# Altium schematic sheet 3phasedriver.SchDoc(3phasedriver)
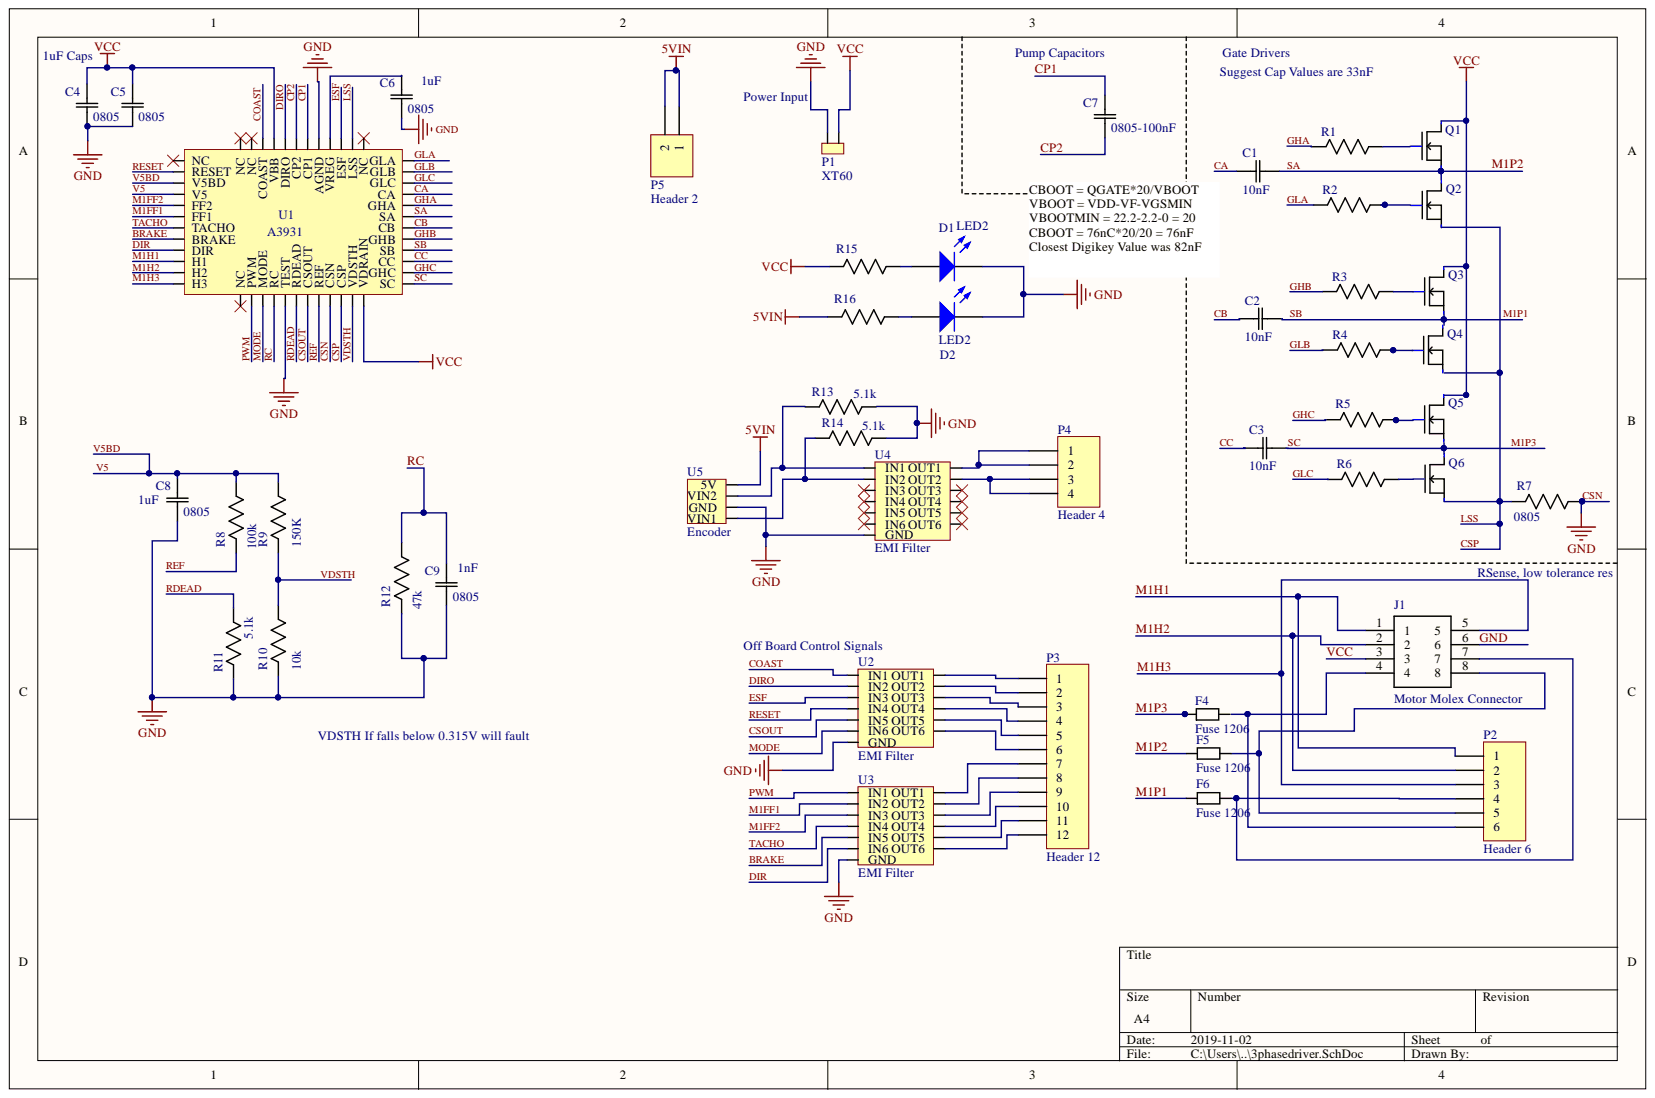
[diagram p0: 3phasedriver.SchDoc]

## Parts
C1  10nF  [CAPC2013X110N]
C2  10nF  [CAPC2013X110N]
C3  10nF  [CAPC2013X110N]
C4  0805  [CAPC2013X110N]
C5  0805  [CAPC2013X110N]
C6  0805  [CAPC2013X110N]
C7  0805-100nF  [CAPC2013X110N]
C8  0805  [CAPC2013X110N]
C9  0805  [CAPC2013X110N]
D1  LED2  [3.2X1.6X1.1]
D2  LED2  [3.2X1.6X1.1]
F4  Fuse 1206  [PCBComponent_1]
F5  Fuse 1206  [PCBComponent_1]
F6  Fuse 1206  [PCBComponent_1]
J1  Motor Molex Connector  MF: Molex  [*MOLEX_0039281083]
P1  XT60  [PCBComponent_1]
P2  Header 6  [HDR1X6]
P3  Header 12  [HDR1X12]
P4  Header 4  [HDR1X4]
P5  Header 2  [HDR1X2]
Q1  N-Channel  [SOIC127P600X170-8N]  (value not drawn)
Q2  N-Channel  [SOIC127P600X170-8N]  (value not drawn)
Q3  N-Channel  [SOIC127P600X170-8N]  (value not drawn)
Q4  N-Channel  [SOIC127P600X170-8N]  (value not drawn)
Q5  N-Channel  [SOIC127P600X170-8N]  (value not drawn)
Q6  N-Channel  [SOIC127P600X170-8N]  (value not drawn)
R1  RES SMD 0 OHM JUMPER 1/8W 0805  [RESC2013X65N]  (value not drawn)
R2  RES SMD 0 OHM JUMPER 1/8W 0805  [RESC2013X65N]  (value not drawn)
R3  RES SMD 0 OHM JUMPER 1/8W 0805  [RESC2013X65N]  (value not drawn)
R4  RES SMD 0 OHM JUMPER 1/8W 0805  [RESC2013X65N]  (value not drawn)
R5  RES SMD 0 OHM JUMPER 1/8W 0805  [RESC2013X65N]  (value not drawn)
R6  RES SMD 0 OHM JUMPER 1/8W 0805  [RESC2013X65N]  (value not drawn)
R7  0805  [RESC2013X65N]
R8  100k  [RESC2013X65N]
R9  150K  [RESC2013X65N]
R10  10k  [RESC2013X65N]
R11  5.1k  [RESC2013X65N]
R12  47k  [RESC2013X65N]
R13  5.1k  [RESC2013X65N]
R14  5.1k  [RESC2013X65N]
R15  2.37k  [RESC2013X65N]  (value not drawn)
R16  330  [RESC2013X65N]  (value not drawn)
U1  A3931  [CQFP50P900X900X160-48N]
U2  EMI Filter  [Filter]
U3  EMI Filter  [Filter]
U4  EMI Filter  [Filter]
U5  Encoder  [PCBComponent_1]

## Nets
5VIN: P5.1 P5.2 R16.2 U5.5V
BRAKE: U1.8(BRAKE) U3.5(IN5)
CA: C1.1 U1.33(CA)
CB: C2.1 U1.30(CB)
CC: C3.1 U1.27(CC)
COAST: U1.46(COAST) U2.1(IN1)
CP1: C7.2 U1.42(CP1)
CP2: C7.1 U1.43(CP2)
CSN: C4.1 C5.1 C6.1 C8.1 C9.1 D1.2 D2.2 J1.6 P1.2 R7.1 R10.2 R11.2 R12.2 U1.17(TEST) U1.21(CSN) U1.41(AGND) U2.GND U3.GND U4.GND U5.GND
CSOUT: U1.19(CSOUT) U2.5(IN5)
CSP: R7.2 U1.22(CSP) U1.38(LSS)
DIR: U1.9(DIR) U3.6(IN6)
DIRO: U1.44(DIRO) U2.2(IN2)
ESF: U1.39(ESF) U2.3(IN3)
GHA: R1.2 U1.32(GHA)
GHB: U1.29(GHB)
GHC: R5.2 U1.26(GHC)
GLA: U1.36(GLA)
GLB: R4.2 U1.35(GLB)
GLC: R6.2 U1.34(GLC)
M1FF1: U1.6(FF1) U3.2(IN2)
M1FF2: U1.5(FF2) U3.3(IN3)
M1H1: J1.1 P2.1 U1.10(H1)
M1H2: J1.2 P2.2 U1.11(H2)
M1H3: J1.5 P2.3 U1.12(H3)
M1P1: C2.2 F6.1 U1.28(SB)
M1P2: C1.2 F5.1 Q1.S U1.31(SA)
M1P3: C3.2 F4.1 U1.25(SC)
MODE: U1.15(MODE) U2.6(IN6)
PWM: U1.14(PWM) U3.1(IN1)
RC: C9.2 R12.1 U1.16(RC)
RDEAD: R11.1 U1.18(RDEAD)
REF: R8.2 U1.20(REF)
RESET: U1.2(RESET) U2.4(IN4)
TACHO: U1.7(TACHO) U3.4(IN4)
V5: C8.2 R8.1 R9.1 U1.3(V5BD) U1.4(V5)
VCC: C4.2 C5.2 J1.3 P1.1 Q1.D R15.2 U1.24(VDRAIN) U1.45(VBB)
VDSTH: R9.2 R10.1 U1.23(VDSTH)
NetC6_2: C6.2 U1.40(VREG)
NetD1_1: D1.1 R15.1
NetD2_1: D2.1 R16.1
NetF4_2: F4.2
NetF5_2: F5.2 J1.8 P2.5
NetF6_2: F6.2 J1.7 P2.4
NetJ1_4: J1.4 P2.6
NetP3_1: P3.1 U2.7(OUT1)
NetP3_2: P3.2 U2.8(OUT2)
NetP3_3: P3.3 U2.9(OUT3)
NetP3_4: P3.4 U2.10(OUT4)
NetP3_5: P3.5 U2.11(OUT5)
NetP3_6: P3.6 U2.12(OUT6)
NetP3_7: P3.7 U3.7(OUT1)
NetP3_8: P3.8 U3.8(OUT2)
NetP3_9: P3.9 U3.9(OUT3)
NetP3_10: P3.10 U3.10(OUT4)
NetP3_11: P3.11 U3.11(OUT5)
NetP3_12: P3.12 U3.12(OUT6)
NetP4_1: P4.1 P4.2 U4.7(OUT1)
NetP4_3: P4.3 P4.4 U4.8(OUT2)
NetQ1_G: Q1.G
NetQ2_G: Q2.G
NetQ3_G: Q3.G
NetQ4_G: Q4.G
NetQ5_G: Q5.G
NetQ6_G: Q6.G
NetR13_2: R13.2
NetR14_2: R14.2
NetU4_1: U4.1(IN1) U5.VIN2
NetU4_2: U4.2(IN2) U5.VIN1
N00030:
N00031:

Not connected: Q2 D S; Q3 D S; Q4 D S; Q5 D S; Q6 D S; R1 1; R2 1 2; R3 1 2; R4 1; R5 1; R6 1; R13 1; R14 1; U1 1(NC) 13(NC) 37(NC) 47(NC) 48(NC); U4 3(IN3) 4(IN4) 5(IN5) 6(IN6) 9(OUT3) 10(OUT4) 11(OUT5) 12(OUT6)

## Library data of the parts
C1-C3 = C0805C104K5RACTU: CAP CER 10000PF 50V X7R 0805; MP: CC0805KRX7R9BB103; MF: Yageo; Value: 10nF; Price: 0,1 CAD; Package: 0805; Library Name: 100nF-C0805C104K5RACTU.IntLib; Digikey Link: https://www.digikey.ca/product-detail/en/yageo/CC0805KRX7R9BB103/311-1136-1-ND/303046; Digikey: 311-1136-1-ND; Component Kind: Standard
C4-C9 = C0805C104K5RACTU: Capacitor; Ceramic; Cap; .100UF ; Tol+-10%; SMD; Vol-Rtg 50V; X7R, Tape/Reel; MF: Kemet; Price: 0.86 USD; Package: 0805 Kemet; MP: C0805C104K5RACTU; Library Name: 100nF-C0805C104K5RACTU.IntLib; Component Kind: Standard; Availability: Good
D1 D2 = LED2: Typical RED, GREEN, YELLOW, AMBER GaAs LED; Simulation: LED2; Published: 8-Jun-2000; PackageVersion: Feb-2002; PackageReference: 3.2X1.6X1.1; PackageDescription: SM LED; 2 Flat Leads; Library Name: Miscellaneous Devices.IntLib; LatestRevisionNote: Re-released for DXP Platform.; LatestRevisionDate: 17-Jul-2002; Component Kind: Standard; Publisher: Altium Limited
F4-F6 = F?: 10A 32V AC 63V DC Fuse Board Mount (Cartridge Style Excluded) Surface Mount 1206 (3216 Metric); MP: 3413.0328.22; MF: Schurter Inc.; Rating: 10A; Library Name: fuse_1206.IntLib; Digikey Link: https://www.digikey.ca/products/en?keywords=486-1686-1-ND; Digikey: 486-1686-1-ND; Component Kind: Standard
J1 = 0039281083: Connector Header Through Hole 8 position 0.165" (4.20mm); Value: N/A; Price: 1.624 CAD; MP: 0039281083; Library Name: 0039281083.IntLib; Digikey Part: WM3803-ND; Component Kind: Standard
P1 U5 = Component_1: Component Kind: Standard
  P1: Library Name: XT60.IntLib
  U5: Library Name: Encoder_Connector.IntLib
P2 = Header 6: Header, 6-Pin; Signal Integrity: Connector; Library Name: Miscellaneous Connectors.IntLib; LatestRevisionNote: Re-released for DXP Platform.; LatestRevisionDate: 17-Jul-2002; Component Kind: Standard; Publisher: Altium Limited
P3 = Header 12: Header, 12-Pin; Signal Integrity: Connector; Library Name: Miscellaneous Connectors.IntLib; LatestRevisionNote: Re-released for DXP Platform.; LatestRevisionDate: 17-Jul-2002; Component Kind: Standard; Publisher: Altium Limited
P4 = Header 4: Header, 4-Pin; Signal Integrity: Connector; Library Name: Miscellaneous Connectors.IntLib; LatestRevisionNote: Re-released for DXP Platform.; LatestRevisionDate: 17-Jul-2002; Component Kind: Standard; Publisher: Altium Limited
P5 = Header 2: Header, 2-Pin; Signal Integrity: Connector; Library Name: Miscellaneous Connectors.IntLib; LatestRevisionNote: Re-released for DXP Platform.; LatestRevisionDate: 17-Jul-2002; Component Kind: Standard; Publisher: Altium Limited
Q1-Q6 = Component_1: MOSFET N-CH 30V 18.5A 8-SOIC; MP: FDS8813NZ; MF: ON Semiconductor; Rating: 30V; Price: 1.727 CAD; Library Name: AO4882.IntLib; Digikey Part: FDS8813NZCT-ND; Component Kind: Standard
R1-R6 = RC0805FR-072K2L: 0 Ohms Jumper 0.125W, 1/8W Chip Resistor 0805 (2012 Metric) Moisture Resistant Thick Film; Value: 0; MP: RC0805JR-070RL; MF: Yageo; Type: Resistor; Rating: 0.125W; Price: 0.058 CAD; Package: 0805; Library Name: RC0805FR-072K2L.IntLib; Component Kind: Standard
R7 = RC0805FR-072K2L: Res Thick Film 0805 2.2K Ohm 1% 0.125W_1/8W_ Â}100ppm/ÂÂŽ Molded SMD Paper T/R; MF: Yageo; SnapEDA_Link: https://www.snapeda.com/parts/RC0805FR-072K2L/Yageo/view-part/?ref=snap; Price: 0.01 USD; Package: 0805 Yageo; MP: RC0805FR-072K2L; Library Name: RC0805FR-072K2L.IntLib; Component Kind: Standard; Availability: Good
R8 = RC0805FR-072K2L: 100 kOhms ±1% 0.125W, 1/8W Chip Resistor 0805 (2012 Metric) Moisture Resistant Thick Film; MP: RC0805FR-07100KL; MF: Yageo; Value: 100k; Price: 0.058 CAD; Package: 0805 (2012 Metric); Library Name: RC0805FR-072K2L.IntLib; Digikey Link: https://www.digikey.ca/product-detail/en/yageo/RC0805FR-07100KL/311-100KCRCT-ND/730491; Digikey: 311-100KCRCT-ND; Component Kind: Standard
R9 = RC0805FR-072K2L: 150 kOhms ±1% 0.125W, 1/8W Chip Resistor 0805 (2012 Metric) Moisture Resistant Thick Film; MF: Yageo; Value: 150k; Price: 0.061 CAD; Package: 0805 (2012 Metric); MP: RC0805FR-072K2L; Library Name: RC0805FR-072K2L.IntLib; Digikey Link: https://www.digikey.ca/products/en?keywords=311-150KCRCT-ND; Digikey: 311-150KCRCT-ND; Component Kind: Standard
R10 = RC0805FR-072K2L: 10 kOhms ±1% 0.125W, 1/8W Chip Resistor 0805 (2012 Metric) Moisture Resistant Thick Film; MP: RC0805FR-0710KL; MF: Yageo; Value: 10k; Price: 0.055 CAD; Package: 0805 (2012 Metric); Library Name: RC0805FR-072K2L.IntLib; Digikey Link: https://www.digikey.ca/products/en?keywords=311-10.0KCRCT-ND; Digikey: 311-10.0KCRCT-ND; Component Kind: Standard
R11 R13 R14 = RC0805FR-072K2L: 5.1 kOhms ±1% 0.125W, 1/8W Chip Resistor 0805 (2012 Metric) Moisture Resistant Thick Film; MP: RC0805FR-075K1L; MF: Yageo; Value: 5.1k; Rating: 0.125W; Price: 0.061 CAD; Package: 0805 (2012 Metric); Library Name: RC0805FR-072K2L.IntLib; Digikey Link: https://www.digikey.ca/product-detail/en/yageo/RC0805FR-075K1L/311-5.10KCRCT-ND/730935; Digikey: 311-5.10KCRCT-ND; Component Kind: Standard
R12 = RC0805FR-072K2L: 47 kOhms ±1% 0.125W, 1/8W Chip Resistor 0805 (2012 Metric) Moisture Resistant Thick Film; MP: RC0805FR-0747KL; MF: Yageo; Value: 47k; Price: 0.061 CAD; Package: 0805 (2012 Metric); Library Name: RC0805FR-072K2L.IntLib; Digikey Link: https://www.digikey.ca/product-detail/en/yageo/RC0805FR-0747KL/311-47.0KCRCT-ND/730920; Digikey: 311-47.0KCRCT-ND; Component Kind: Standard
R15 = RC0805FR-072K2L: 2.37 kOhms ±1% 0.25W, 1/4W Chip Resistor 0805 (2012 Metric) Automotive AEC-Q200, Moisture Resistant Thick Film; MP: RK73H2ATTD2371F; MF: KOA Speer Electronics, Inc.; Value: 2.37k; Price: 0.053 CAD; Package: 0805 (2012 Metric); Library Name: RC0805FR-072K2L.IntLib; Digikey Link: https://www.digikey.ca/product-detail/en/koa-speer-electronics-inc/RK73H2ATTD2371F/2019-RK73H2ATTD2371FCT-ND/10235140; Digikey: 2019-RK73H2ATTD2371FCT-ND; Component Kind: Standard
R16 = RC0805FR-072K2L: RES SMD 330 OHM 1% 1/8W 0805; MP: RC0805FR-07330RL; MF: Yageo; Value: 330; Price: 0.061 CAD; Package: 0805 (2012 Metric); Library Name: RC0805FR-072K2L.IntLib; Digikey Link: https://www.digikey.ca/product-detail/en/yageo/RC0805FR-07330RL/311-330CRCT-ND/730813; Digikey: 311-330CRCT-ND; Component Kind: Standard
U1 = Component_1: Rating: 5.5V ~ 50V; MP: A3931KJPTR-T; MF: Allegro MicroSystems; Price: 5.908 CAD; Digikey Link: https://www.digikey.com/product-detail/en/allegro-microsystems-llc/A3931KJPTR-T/620-1290-1-ND/2000021; Digikey: 620-1290-1-ND; Component Kind: Standard; Library Name: 620-1289-1-ND.IntLib
U2-U4 = EMIFilter: MP: EMIF06-1005M12; MF: STMicroelectronics; Price: 0.49 CAD; Component Kind: Standard; Library Name: 497-7740-1-ND.IntLib
  U2 U3: Digikey Link: https://www.digikey.ca/product-detail/en/stmicroelectronics/EMIF06-1005M12/497-7740-1-ND/1883755; Digikey: 497-7740-1-ND
  U4: Package: 12-UFDFN Exposed Pad; Digikey Link: 497-7740-1-ND
